# Altium schematic sheet RTC.SchDoc(RTC)
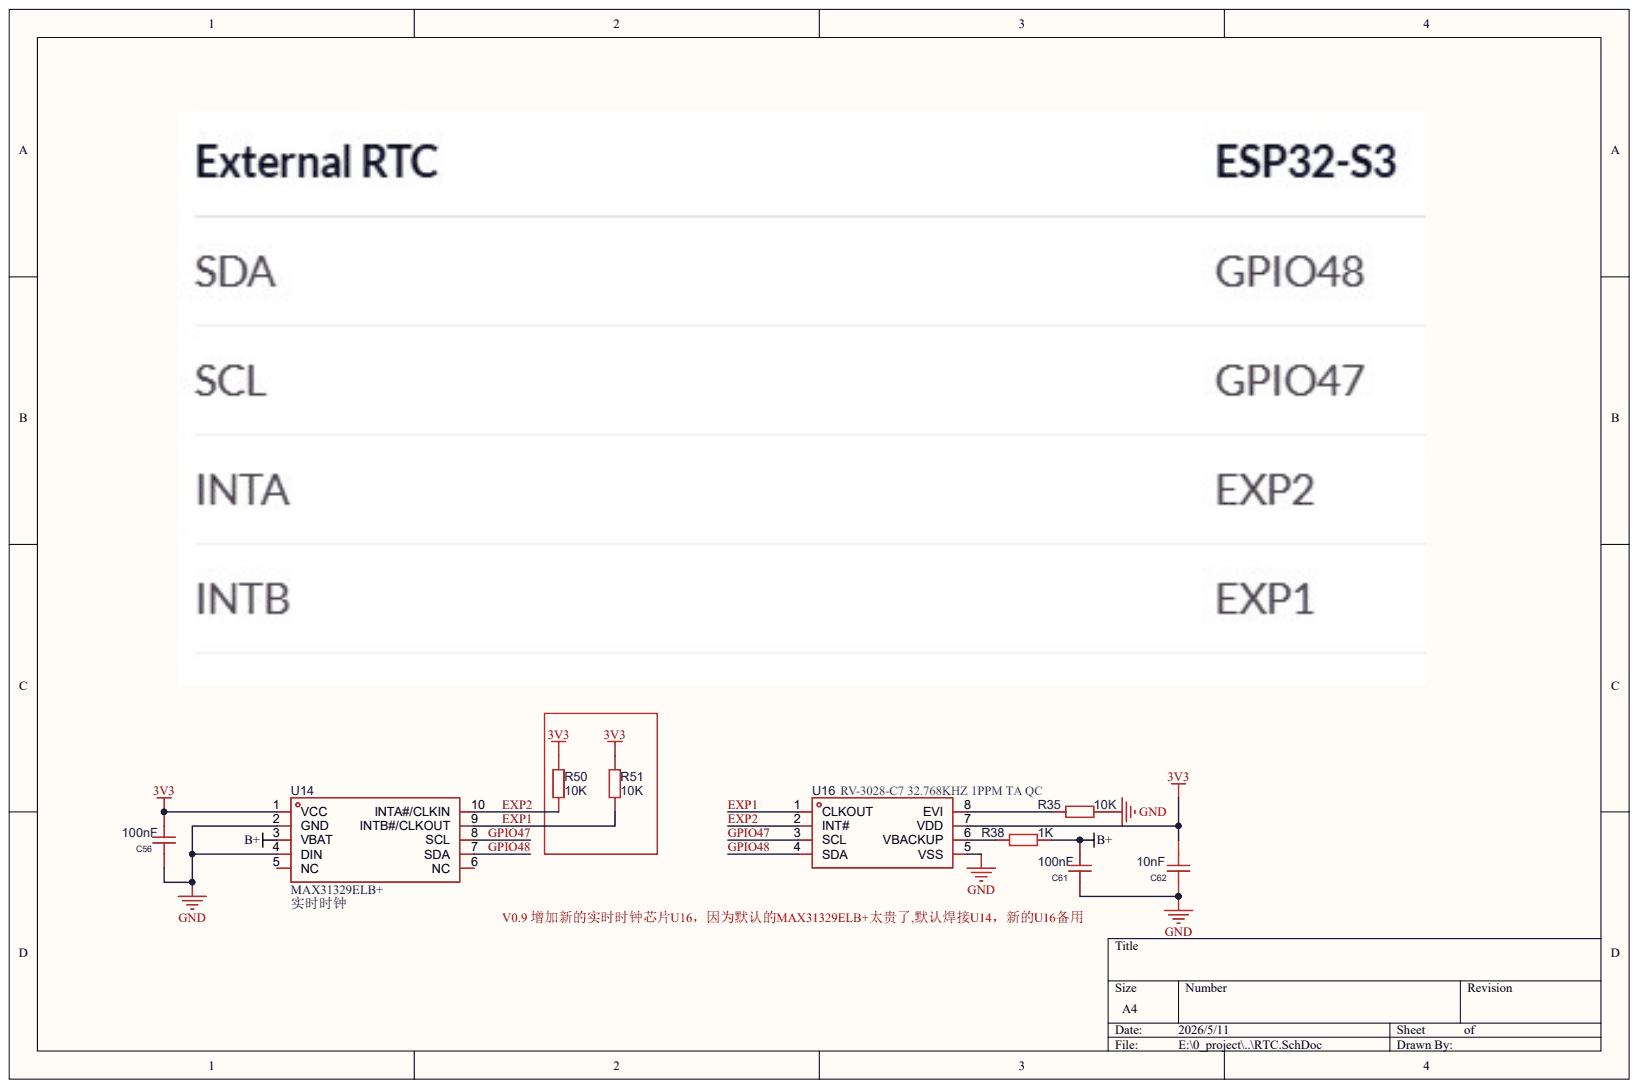
[diagram p0: RTC.SchDoc]

## Parts
C56  100nF
C61  100nF
C62  value 10nF  (hidden comment: 100nF)
R35  10K
R38  value 1K  (hidden comment: 10K)
R50  10K
R51  10K
U14  MAX31329ELB+
U16  RV-3028-C7 32.768KHZ 1PPM TA QC

## Nets
3V3: C56.2 C62.2 R50.2 R51.2 U14.1(VCC) U16.7(VDD)
B+: C61.2 R38.2 U14.3(VBAT)
GND: C56.1 C61.1 C62.1 R35.2 U14.2(GND) U14.4(DIN) U16.5(VSS)
EXP1: R51.1 U14.9(INTB#/CLKOUT) U16.1(CLKOUT)
EXP2: R50.1 U14.10(INTA#/CLKIN) U16.2(INT#)
GPIO47: U14.8(SCL) U16.3(SCL)
GPIO48: U14.7(SDA) U16.4(SDA)
NetR35_1: R35.1 U16.8(EVI)
NetR38_1: R38.1 U16.6(VBACKUP)

Not connected: U14 5(NC) 6(NC)

## Library data of the parts
C56 C61 C62 = (no symbol name): Symbol: GRM155R71H104KE14D; AddInBom: yes; ModelId: 36a51122f27c49738f86b3bfa98863e0; ModelName: C0402_L1.0-W0.5-H0.5; ModelTransform: 39.37,19.685,0,0,0,0,0,0,0; Voltage Rated: 50V; Temperature Coefficient: X7R; Device: GRM155R71H104KE14D; Supplier: LCSC; Manufacturer Part: GRM155R71H104KE14D; Capacitance: 100nF; Supplier Part: C77020; Supplier Footprint: 0402; Datasheet: https://atta.szlcsc.com/upload/public/pdf/source/20180326/C77020_39BD49C951FF1AF0DB1F1FAC5AC1E401.pdf; ConvertToPcb: yes; FootprintName: R0402
  C56 C61: Value: 100nF
R35 R38 = (no symbol name): Device: 0402WGJ0472TCE; AddInBom: yes; ModelId: 671aefced39d4c318694bba8bd8efd39; ModelName: R0402_L1.0-W0.5-H0.5; ModelTransform: 39.37,19.685,0,0,0,0,0,0.0005,0; Power(Watts): 62.5mW; Overload Voltage (Max): 50V; Symbol: 0402WGJ0472TCE; SupplierPart: C25940; ManufacturerPart: 0402WGJ0472TCE; Supplier Footprint: 0402; Datasheet: https://atta.szlcsc.com/upload/public/pdf/source/20200306/C422600_1E6D84923E4A46A82E41ADD87F860B5C.pdf; Supplier: LCSC; ConvertToPcb: yes; FootprintName: R0402
  R35: Value: 10K
R50 R51 = (no symbol name): Device: 0402WGF4990TCE; AddInBom: yes; ModelId: 671aefced39d4c318694bba8bd8efd39; ModelName: R0402_L1.0-W0.5-H0.5; ModelTransform: 39.37,19.685,0,0,0,0,0,0.0005,0; Power(Watts): 62.5mW; Overload Voltage (Max): 50V; Value: 10K; Symbol: 0402WGF4990TCE; SupplierPart: C4125; ManufacturerPart: 0402WGF4990TCE; Supplier Footprint: 0402; JLCPCB Part Class: Extended Part; Datasheet: https://atta.szlcsc.com/upload/public/pdf/source/20200306/C422600_1E6D84923E4A46A82E41ADD87F860B5C.pdf; Supplier: LCSC; ConvertToPcb: yes; FootprintName: R0402
U14 U16 = (no symbol name)
  U14: Symbol: MAX31329ELB+
  U16: Symbol: RV-3028-C7 32.768KHZ 1PPM TA QC
